# Altium schematic sheet 07connectors.SchDoc(07connectors)
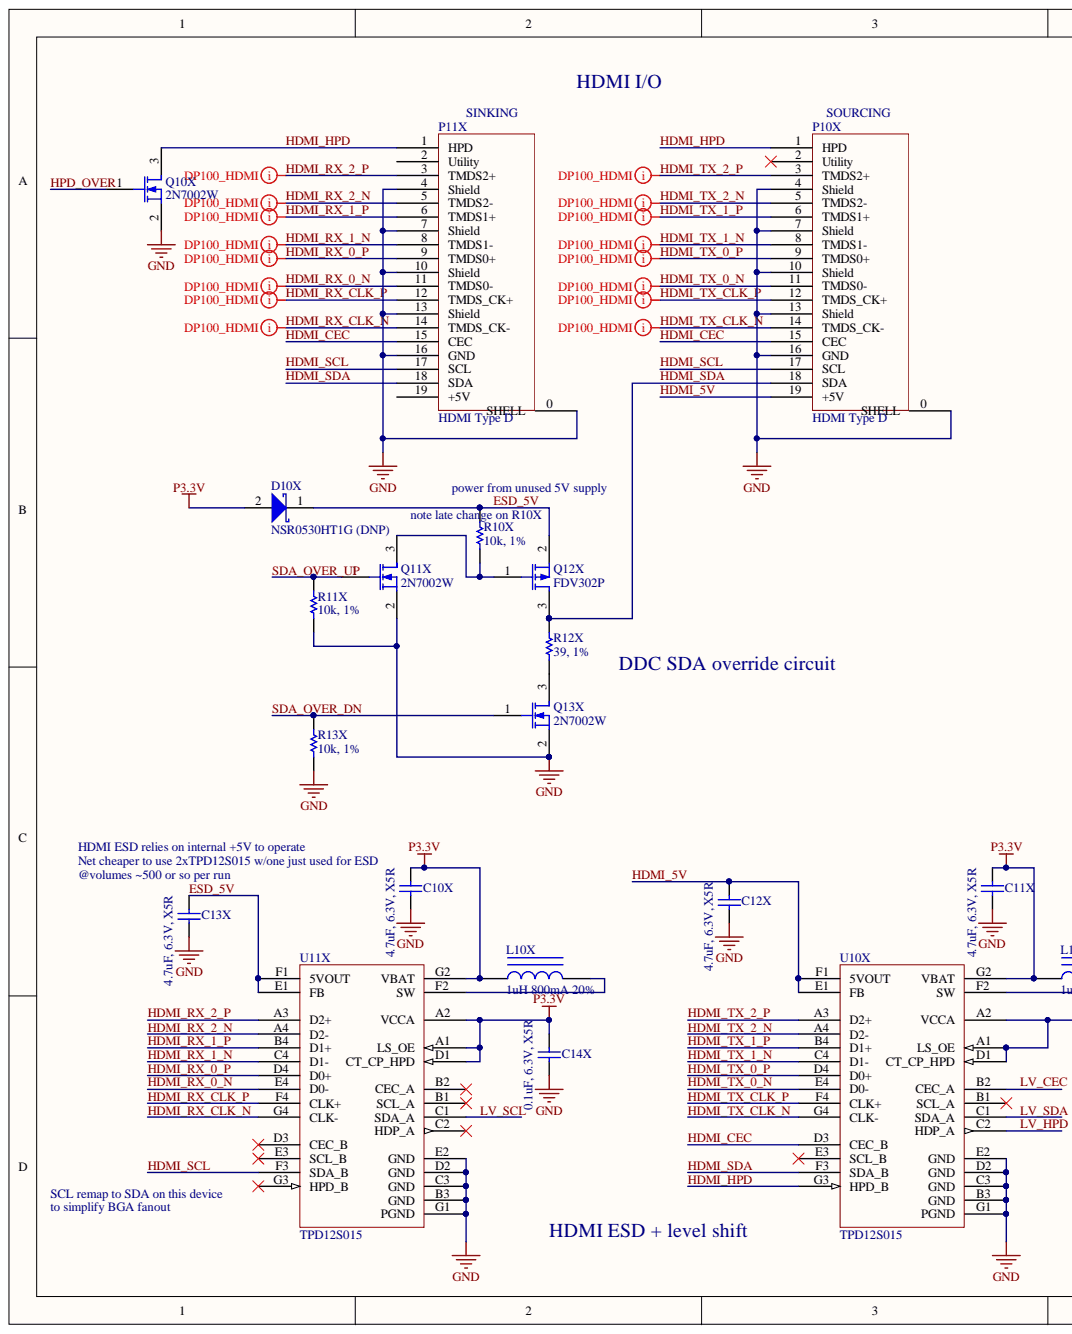
[diagram p0: 07connectors.SchDoc, left]
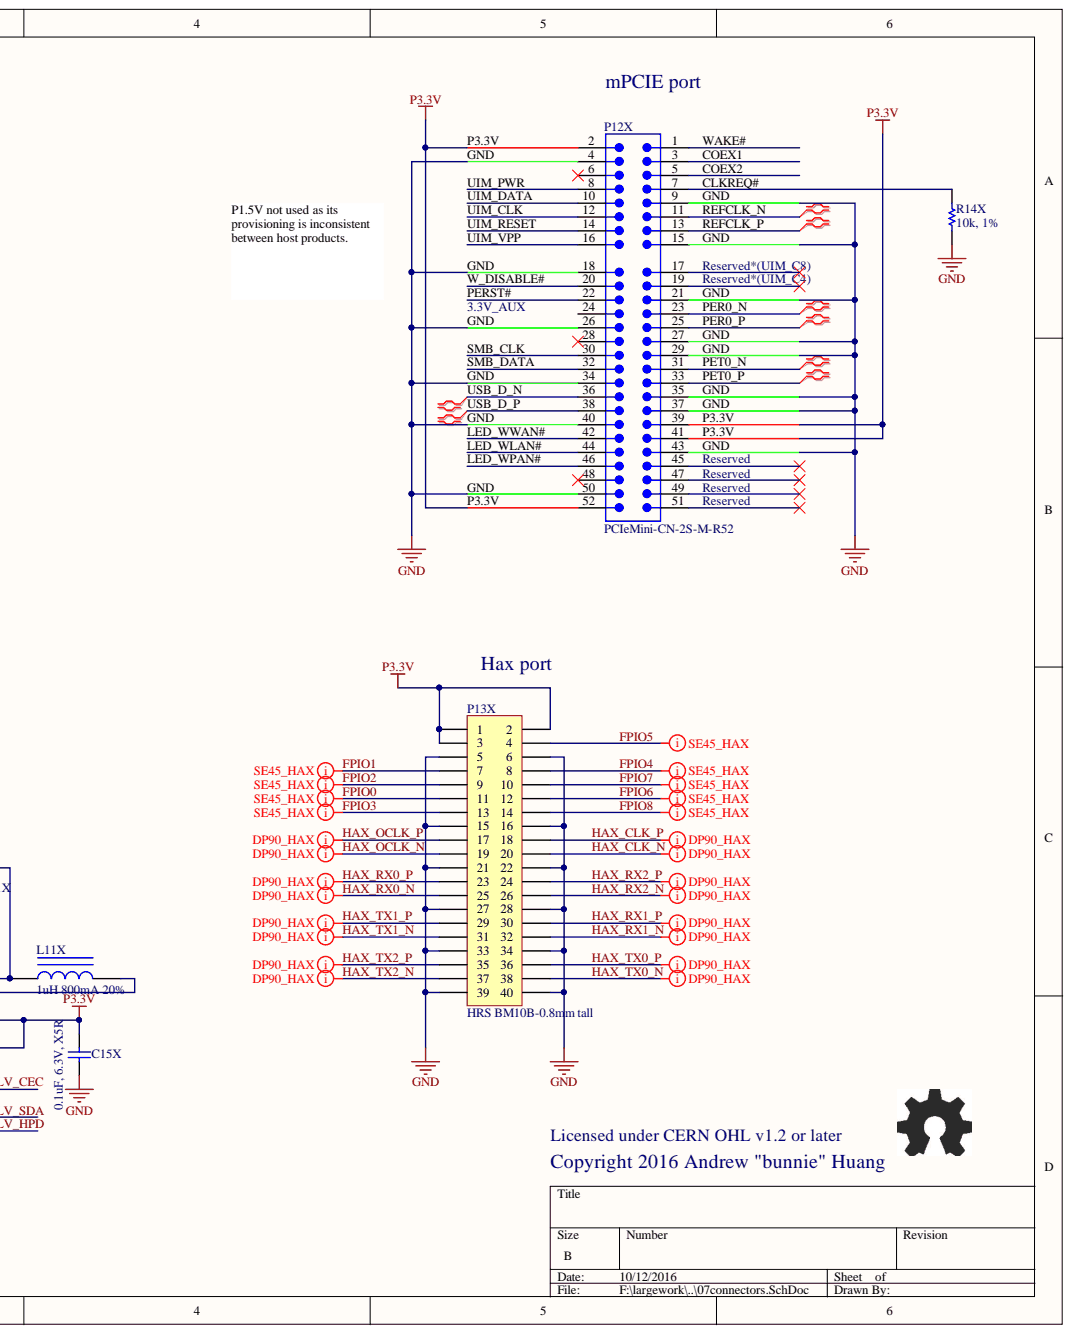
[diagram p1: 07connectors.SchDoc, right]

## Parts
C10X  4.7uF, 6.3V, X5R  [CAPC1005N]
C11X  4.7uF, 6.3V, X5R  [CAPC1005N]
C12X  4.7uF, 6.3V, X5R  [CAPC1005N]
C13X  4.7uF, 6.3V, X5R  [CAPC1005N]
C14X  0.1uF, 6.3V, X5R  [CAPC0603X03L]
C15X  0.1uF, 6.3V, X5R  [CAPC0603X03L]
D10X  NSR0530HT1G (DNP)  [SOD-323]
L10X  1uH 800mA 20%  [INDC2012N]
L11X  1uH 800mA 20%  [INDC2012N]
P10X  HDMI Type D  [MOLEX_0467651301]
P11X  HDMI Type D  [MOLEX_0467651301]
P12X  PCIeMini-CN-2S-M-R52  [mPCIE-outline]  (no BOM)
P13X  HRS BM10B-0.8mm tall  [HRS_BM10B(0.6)-40DP-0.4V(51)]
Q10X  2N7002W  [SOT323-3AN]
Q11X  2N7002W  [SOT323-3AN]
Q12X  FDV302P  [SOT23-3N]
Q13X  2N7002W  [SOT323-3AN]
R10X  10k, 1%  [CAPC0603X03L]
R11X  10k, 1%  [CAPC0603X03L]
R12X  39, 1%  [RESC1005N]
R13X  10k, 1%  [CAPC0603X03L]
R14X  10k, 1%  [CAPC0603X03L]
U10X  TPD12S015  [TI-YFF-R-XBGA-28]
U11X  TPD12S015  [TI-YFF-R-XBGA-28]

## Nets
CLKREQ#: P12X.7 R14X.2
COEX1: P12X.3
COEX2: P12X.5
ESD_5V: C13X.1 D10X.1 Q12X.2 R10X.1 U11X.E1(FB) U11X.F1(5VOUT)
FPIO0: P13X.11
FPIO1: P13X.7
FPIO2: P13X.9
FPIO3: P13X.13
FPIO4: P13X.8
FPIO5: P13X.4
FPIO6: P13X.12
FPIO7: P13X.10
FPIO8: P13X.14
GND: C10X.2 C11X.2 C12X.2 C13X.2 C14X.2 C15X.2 P10X.0(SHELL) P10X.4(Shield) P10X.7(Shield) P10X.10(Shield) P10X.13(Shield) P10X.16(GND) P11X.0(SHELL) P11X.4(Shield) P11X.7(Shield) P11X.10(Shield) P11X.13(Shield) P11X.16(GND) P12X.4 P12X.9 P12X.15 P12X.18 P12X.21 P12X.26 P12X.27 P12X.29 P12X.34 P12X.35 P12X.37 P12X.40 P12X.43 P12X.50 P13X.5 P13X.6 P13X.15 P13X.16 P13X.21 P13X.22 P13X.27 P13X.28 P13X.33 P13X.34 P13X.39 P13X.40 Q10X.2 Q11X.2 Q13X.2 R11X.1 R13X.1 R14X.1 U10X.B3(GND) U10X.C3(GND) U10X.D2(GND) U10X.E2(GND) U10X.G1(PGND) U11X.B3(GND) U11X.C3(GND) U11X.D2(GND) U11X.E2(GND) U11X.G1(PGND)
HAX_CLK_N: P13X.20
HAX_CLK_P: P13X.18
HAX_OCLK_N: P13X.19
HAX_OCLK_P: P13X.17
HAX_RX0_N: P13X.25
HAX_RX0_P: P13X.23
HAX_RX1_N: P13X.32
HAX_RX1_P: P13X.30
HAX_RX2_N: P13X.26
HAX_RX2_P: P13X.24
HAX_TX0_N: P13X.38
HAX_TX0_P: P13X.36
HAX_TX1_N: P13X.31
HAX_TX1_P: P13X.29
HAX_TX2_N: P13X.37
HAX_TX2_P: P13X.35
HDMI_5V: C12X.1 P10X.19(+5V) U10X.E1(FB) U10X.F1(5VOUT)
HDMI_CEC: P10X.15(CEC) P11X.15(CEC) U10X.D3(CEC_B)
HDMI_HPD: P10X.1(HPD) P11X.1(HPD) Q10X.3 U10X.G3(HPD_B)
HDMI_RX_0_N: P11X.11(TMDS0-) U11X.E4(D0-)
HDMI_RX_0_P: P11X.9(TMDS0+) U11X.D4(D0+)
HDMI_RX_1_N: P11X.8(TMDS1-) U11X.C4(D1-)
HDMI_RX_1_P: P11X.6(TMDS1+) U11X.B4(D1+)
HDMI_RX_2_N: P11X.5(TMDS2-) U11X.A4(D2-)
HDMI_RX_2_P: P11X.3(TMDS2+) U11X.A3(D2+)
HDMI_RX_CLK_N: P11X.14(TMDS_CK-) U11X.G4(CLK-)
HDMI_RX_CLK_P: P11X.12(TMDS_CK+) U11X.F4(CLK+)
HDMI_SCL: P10X.17(SCL) P11X.17(SCL) U11X.F3(SDA_B)
HDMI_SDA: P10X.18(SDA) P11X.18(SDA) Q12X.3 R12X.2 U10X.F3(SDA_B)
HDMI_TX_0_N: P10X.11(TMDS0-) U10X.E4(D0-)
HDMI_TX_0_P: P10X.9(TMDS0+) U10X.D4(D0+)
HDMI_TX_1_N: P10X.8(TMDS1-) U10X.C4(D1-)
HDMI_TX_1_P: P10X.6(TMDS1+) U10X.B4(D1+)
HDMI_TX_2_N: P10X.5(TMDS2-) U10X.A4(D2-)
HDMI_TX_2_P: P10X.3(TMDS2+) U10X.A3(D2+)
HDMI_TX_CLK_N: P10X.14(TMDS_CK-) U10X.G4(CLK-)
HDMI_TX_CLK_P: P10X.12(TMDS_CK+) U10X.F4(CLK+)
HPD_OVER: Q10X.1
LED_WLAN#: P12X.44
LED_WPAN#: P12X.46
LED_WWAN#: P12X.42
LV_CEC: U10X.B2(CEC_A)
LV_HPD: U10X.C2(HDP_A)
LV_SCL: U11X.C1(SDA_A)
LV_SDA: U10X.C1(SDA_A)
P3.3V: C10X.1 C11X.1 C14X.1 C15X.1 D10X.2 L10X.1 L11X.1 P12X.2 P12X.39 P12X.41 P12X.52 P13X.1 P13X.2 P13X.3 U10X.A1(LS_OE) U10X.A2(VCCA) U10X.D1(CT_CP_HPD) U10X.G2(VBAT) U11X.A1(LS_OE) U11X.A2(VCCA) U11X.D1(CT_CP_HPD) U11X.G2(VBAT)
PER0_N: P12X.23
PER0_P: P12X.25
PERST#: P12X.22
PET0_N: P12X.31
PET0_P: P12X.33
REFCLK_N: P12X.11
REFCLK_P: P12X.13
SDA_OVER_DN: Q13X.1 R13X.2
SDA_OVER_UP: Q11X.1 R11X.2
SMB_CLK: P12X.30
SMB_DATA: P12X.32
UIM_CLK: P12X.12
UIM_DATA: P12X.10
UIM_PWR: P12X.8
UIM_RESET: P12X.14
UIM_VPP: P12X.16
USB_D_N: P12X.36
USB_D_P: P12X.38
W_DISABLE#: P12X.20
WAKE#: P12X.1
NetL10X_2: L10X.2 U11X.F2(SW)
NetL11X_2: L11X.2 U10X.F2(SW)
NetQ11X_3: Q11X.3 Q12X.1 R10X.2
NetQ13X_3: Q13X.3 R12X.1

Not connected: P10X 2(Utility); P11X 2(Utility) 19(+5V); P12X 6 17 19 24 28 45 47 48 49 51; U10X B1(SCL_A) E3(SCL_B); U11X B1(SCL_A) B2(CEC_A) C2(HDP_A) D3(CEC_B) E3(SCL_B) G3(HPD_B)

## Library data of the parts
C10X C11X C12X C13X = Cap Semi: Capacitor (Semiconductor SIM Model); MPN: CL05A475MQ5NQNC; MYPN: C-475-6p3-X5R-0402; VENDOR: Samsung; Component Kind: Standard; Library Name: Miscellaneous Devices.IntLib; Signal Integrity: Cap Semi; Simulation: CAP
C14X C15X = Cap Semi: Capacitor (Semiconductor SIM Model); MPN: CL03A104KQ3NNNC; MYPN: C-104-6p3-X5R-0201; VENDOR: Samsung; Component Kind: Standard; Library Name: Miscellaneous Devices.IntLib; Signal Integrity: Cap Semi; Simulation: CAP
D10X = D Schottky: Schottky Diode; MPN: NSR0530H-D; VENDOR: Semtech HK LTD; Component Kind: Standard; Library Name: Miscellaneous Devices.IntLib; MYPN: DNP; Revision: July-2002: Re-released for DXP Platform.; Signal Integrity: D Schottky; Simulation: SKYDIODE
L10X L11X = Inductor Iron: Magnetic-Core Inductor; MPN: LQM21PN1R0MC0D; MYPN: L-1R0-0805; VENDOR: Murata; Component Kind: Standard; Library Name: Miscellaneous Devices.IntLib; Signal Integrity: Inductor Iron; Simulation: INDUCTOR
P10X P11X = HDMI-TYPE-D: HDMI Type D with through-hole mounts; MPN: 0467651301; MYPN: J-HDMI-D-THMNT; VENDOR: Molex; Component Kind: Standard; Library Name: commonsch-new.SchLib
P12X = CMP-0001-00067-2: Golden finger, PCIeMini, Dual, Staggered, Pitch 0.8mm, 52-Position; Mating Connector: Tyco-292443-1; Package Version: rev1.2, Oct-2007; ClassName: Edge Connector; Datasheet Version: Revision 1.2 Oct-2007; Package Reference: PCIeMini-GF-2D-800-1K-S52; Publisher: Altium
P13X = Header 20X2: Header, 20-Pin, Dual row; MPN: BM10B(0.8)-40DP-0.4V(51); MYPN: J-BM10B(0.8)-40DP-0.4V; VENDOR: Hirose; Component Kind: Standard; LatestRevisionDate: 17-Jul-2002; LatestRevisionNote: Re-released for DXP Platform.; Library Name: Miscellaneous Connectors.IntLib; Signal Integrity: Connector; Publisher: Altium Limited
Q10X Q11X Q13X = MOSFET-N: N-Channel MOSFET; MPN: 2N7002WT1G; MYPN: Q-2N7002W; VENDOR: ON Semiconductor; Component Kind: Standard; LatestRevisionDate: 17-Jul-2002; LatestRevisionNote: Re-released for DXP Platform.; Library Name: Miscellaneous Devices.IntLib; PackageReference: E3; PackageVersion: 1995/1996; Published: 8-Jun-2000; Simulation: NMOS; Publisher: Altium Limited
Q12X = MOSFET-P: P-Channel MOSFET; MYPN: Q-FDV302P; VENDOR: Fairchild Semiconductor; Component Kind: Standard; LatestRevisionDate: 17-Jul-2002; LatestRevisionNote: Re-released for DXP Platform.; Library Name: Miscellaneous Devices.IntLib; MPN: FDV302P; PackageDescription: TO, Flat Index; 3 In-Line, Axial Leads; Body Dia. 4.6mm; Lead Dia. 0.5 mm (max); PackageReference: E3; PackageVersion: 1995/1996; Published: 8-Jun-2000; Simulation: PMOS; Publisher: Altium Limited
R10X R11X R13X R14X = Res1: Resistor; MPN: ANY; MYPN: R-103-1P-1_16W-0201; Component Kind: Standard; Library Name: Miscellaneous Devices.IntLib; Signal Integrity: Res; Simulation: RESISTOR; VENDOR: ANY
R12X = Res1: Resistor; MPN: ANY; MYPN: R-390-1P-1_16W-0402; Component Kind: Standard; Library Name: Miscellaneous Devices.IntLib; Signal Integrity: Res; Simulation: RESISTOR; VENDOR: ANY
U10X U11X = TPD12S015: HDMI Companion chip with step-up DCDC, level shifter and ESD; MPN: TPD12S015YFFR; MYPN: UTPD12S015YFFR; VENDOR: Texas Instruments; Component Kind: Standard; Library Name: commonsch-new.SchLib
